# Altium schematic sheet receiver4.SchDoc(receiver4)
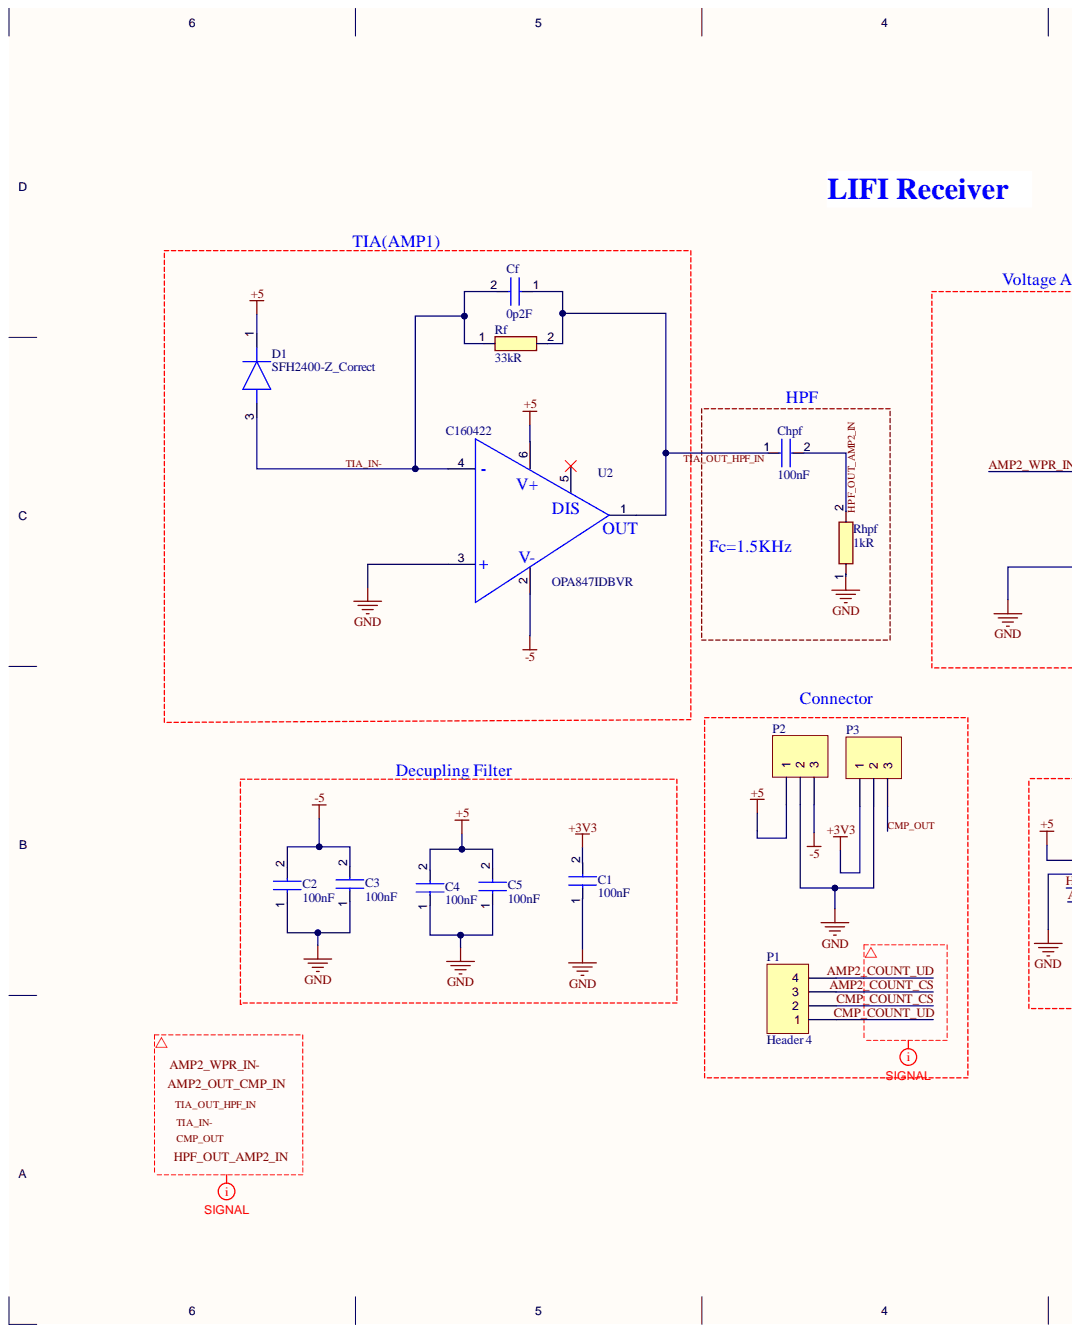
[diagram p0: receiver4.SchDoc, left]
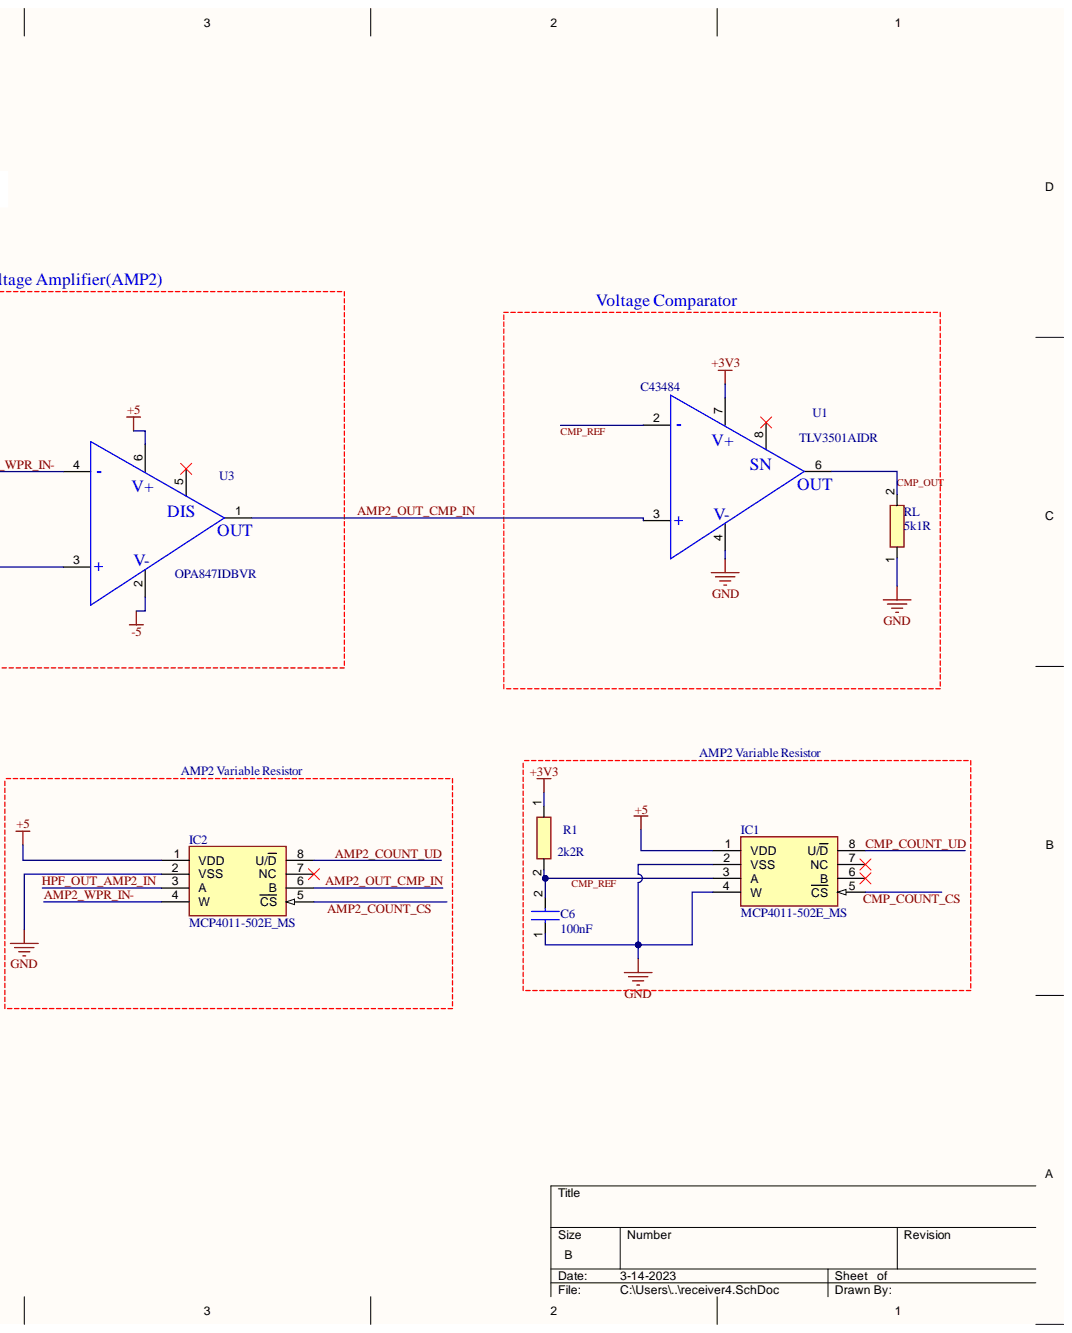
[diagram p1: receiver4.SchDoc, right]

## Parts
C1  100nF  [0603C]
C2  100nF  [0603C]
C3  100nF  [0603C]
C4  100nF  [0603C]
C5  100nF  [0603C]
C6  100nF  [0603C]
Cf  0p2F  [0402C]
Chpf  100nF  [0603C]
D1  SFH2400-Z_Correct  [SFH 2400-Z - duplicate]
IC1  MCP4011-502E_MS  [MCP4011_SOP65P490X110-8N]
IC2  MCP4011-502E_MS  [MCP4011_SOP65P490X110-8N]
P1  Header 4  [HDR1X4]
P2  (no value)  [HDR1X3]
P3  ⠀  [HDR1X3]  (value not drawn)
R1  2k2R  [0402R]
Rf  33kR  [0603R]
Rhpf  1kR  [0603R]
RL  5k1R  [0603R]
U1  TLV3501AIDR  Supplier Part Number 1: C43484  [TLV3501AIDR]
U2  OPA847IDBVR  Supplier Part Number 1: C160422  [OPA847IDBVR]
U3  OPA847IDBVR  [OPA847IDBVR]

## Nets
+3V3: C1.2 P3.1 R1.1 U1.7(V+)
+5: C4.2 C5.2 D1.1 IC1.1(VDD) IC2.1(VDD) P2.1 U2.6(V+) U3.6(V+)
-5: C2.2 C3.2 P2.3 U2.2(V-) U3.2(V-)
GND: C1.1 C2.1 C3.1 C4.1 C5.1 C6.1 IC1.2(VSS) IC1.4(W) IC2.2(VSS) P2.2 P3.2 Rhpf.1 RL.1 U1.4(V-) U2.3(+) U3.3(+)
AMP2_COUNT_CS: IC2.5(CS) P1.3
AMP2_COUNT_UD: IC2.8(U/D) P1.4
AMP2_OUT_CMP_IN: IC2.6(B) U1.3(+) U3.1(DIS)
AMP2_WPR_IN-: IC2.4(W) U3.4(-)
CMP_COUNT_CS: IC1.5(CS) P1.2
CMP_COUNT_UD: IC1.8(U/D) P1.1
CMP_OUT: P3.3 RL.2 U1.6
CMP_REF: C6.2 IC1.3(A) R1.2 U1.2(-)
HPF_OUT_AMP2_IN: Chpf.2 IC2.3(A) Rhpf.2
TIA_IN-: Cf.2 D1.3 Rf.1 U2.4(-)
TIA_OUT_HPF_IN: Cf.1 Chpf.1 Rf.2 U2.1(DIS)

Not connected: IC1 6(B) 7(NC); IC2 7(NC); U1 8(SN); U2 5; U3 5

## Library data of the parts
C1-C6 Chpf = 0603C: Component Kind: Standard; Library Name: Schlib_Receiver.SchLib
Cf = 0402C: Component Kind: Standard; Library Name: Schlib_Receiver.SchLib
D1 = SFH2400-Z_Correct: Silicon PIN photodiode, SMT, SFH2400; Manufacturer: Osram; Dark Current: 1nA; Fall Time: 5ns; Forward Voltage: 1.3V; Lens Style: TRANSPARENT; Max Power Dissipation: 120mW; Max Reverse Voltage (DC): 20V; Responsivity: 0.65A/W; Reverse Breakdown Voltage: 50V; Viewing Angle: 120°; Wavelength: 850nm; Case/Package: SMD/SMT; Category: LEDs; Component Kind: Standard; ComponentLink1Description: https://datasheet.ciiva.com/4697/fh2400-rpb-free-2011-02-08-16784-4697649.pdf?src-supplier=Mouser; ComponentLink1URL: https://datasheet.ciiva.com/4697/fh2400-rpb-free-2011-02-08-16784-4697649.pdf?src-supplier=Mouser; ComponentLink2Description: https://datasheet.ciiva.com/1248/36138-1248870.pdf?src-supplier=Element14; ComponentLink2URL: https://datasheet.ciiva.com/1248/36138-1248870.pdf?src-supplier=Element14; ComponentLink3Description: https://datasheet.ciiva.com/2820/00131266-0-2820020.pdf?src-supplier=Digi-Key; ComponentLink3URL: https://datasheet.ciiva.com/2820/00131266-0-2820020.pdf?src-supplier=Digi-Key; ComponentLink4Description: https://datasheet.ciiva.com/2820/00131266-0-2820021.pdf?src-supplier=Digi-Key; ComponentLink4URL: https://datasheet.ciiva.com/2820/00131266-0-2820021.pdf?src-supplier=Digi-Key; ComponentLink5Description: https://datasheet.ciiva.com/2820/00131266-0-2820022.pdf?src-supplier=Digi-Key; ComponentLink5URL: https://datasheet.ciiva.com/2820/00131266-0-2820022.pdf?src-supplier=Digi-Key; ComponentLink6Description: https://datasheet.ciiva.com/1248/36138-1248871.pdf?src-supplier=Element14; ComponentLink6URL: https://datasheet.ciiva.com/1248/36138-1248871.pdf?src-supplier=Element14; Diode Type: PIN; Height: 1.05mm; Length: 2.1mm; Library Name: Schlib_Receiver.SchLib; Manufacturer Part Number: SFH2400-Z; Max Operating Temperature: 100°C; Min Operating Temperature: -40°C; Mount: Surface Mount; Number of Pins: 3; Package Quantity: 1; Packaging: Tape and Reel; Power Dissipation: 120mW; Pricing 1: 1=1.19000, 10=0.75200, 50=0.75200, 100=0.55700, 1000=0.42200, 10000=0.38900 (USD); Radiation Hardening: No; REACH SVHC: No SVHC; Response Time: 5ns; Reverse Voltage (DC): 20V; Rise Time: 5ns; RoHS: Yes; RoHS Compliant: Yes; Series: -; Stock 1: 10986; Supplier 1: Mouser; Width: 2.7mm
IC1 IC2 = MCP4011-502E_MS: Integrated Circuit; Manufacturer_Name: Microchip; Manufacturer_Part_Number: MCP4011-502E/MS; Arrow Part Number: MCP4011-502E/MS; Arrow Price/Stock: https://www.arrow.com/en/products/mcp4011-502ems/microchip-technology?region=nac; Component Kind: Standard; Datasheet Link: https://datasheet.datasheetarchive.com/originals/distributors/SFDatasheet-4/sf-00090078.pdf; Height: 1.1mm; Library Name: Schlib_Receiver.SchLib; Mouser Part Number: 579-MCP4011-502E/MS; Mouser Price/Stock: https://www.mouser.co.uk/ProductDetail/Microchip-Technology/MCP4011-502E-MS?qs=usxtMOJb1RzQTLKzDsZHHA%3D%3D
P1 = Header 4: Header, 4-Pin; Component Kind: Standard; LatestRevisionDate: 17-Jul-2002; LatestRevisionNote: Re-released for DXP Platform.; Library Name: Miscellaneous Connectors.IntLib; Signal Integrity: Connector; Publisher: Altium Limited
P2 P3 = Header 3: Header, 3-Pin; Component Kind: Standard; LatestRevisionDate: 17-Jul-2002; LatestRevisionNote: Re-released for DXP Platform.; Library Name: Miscellaneous Connectors.IntLib; Signal Integrity: Connector; Publisher: Altium Limited
R1 = 0402R: Component Kind: Standard; Library Name: Schlib_Receiver.SchLib
Rf Rhpf RL = 0603R: Component Kind: Standard; Library Name: Schlib_Receiver.SchLib
U1 = TLV3501AIDR: Comparator General Purpose CMOS, Push-Pull, Rail-to-Rail, TTL 8-SOIC; Manufacturer: Texas Instruments; Common Mode Rejection Ratio: 70dB; Hysteresis: 6mV; Input Bias Current: 10pA; Input Offset Voltage (Vos): 6.5mV; Max Supply Current: 5mA; Max Supply Voltage: 5.5V; Min Supply Voltage: 2.7V; Number of Channels: 1; Output Current: 74mA; Output Type: TTL,PUSH-PULL; Power Supply Rejection Ratio (PSRR): 100dB; Propagation Delay: 10ns; Response Time: 0.0045us; Case/Package: SOIC; Category: Amplifiers - Op Amps, Buffer, Instrumentation; Component Kind: Standard; ComponentLink1Description: https://datasheet.ciiva.com/1830/tlv3501-1830016.pdf?src-supplier=Digi-Key; ComponentLink1URL: https://datasheet.ciiva.com/1830/tlv3501-1830016.pdf?src-supplier=Digi-Key; ComponentLink2Description: https://datasheet.ciiva.com/14392/tlv3501-14392108.pdf?src-supplier=Avnet; ComponentLink2URL: https://datasheet.ciiva.com/14392/tlv3501-14392108.pdf?src-supplier=Avnet; Lead Free: Lead Free; Library Name: Schlib_Receiver.SchLib; Manufacturer Part Number: TLV3501AIDR; Max Input Current: 10pA; Max Operating Temperature: 125°C; Min Operating Temperature: -40°C; Mount: Surface Mount; Nominal Supply Current: 5mA; Number of Elements: 1; Number of Pins: 8; Package Quantity: 2500; Packaging: Tape and Reel; Pricing 1: 1=3.137700, 10=2.699600, 30=2.427300, 100=2.145900, 500=2.019700, 1000=1.963800 (AUD); Quiescent Current: 5mA; Radiation Hardening: No; RoHS: Yes; RoHS Compliant: Yes; Series: -; Stock 1: 1957; Supplier 1: LCSC; Supply Current: 5mA; Technology: CMOS; Type: General Purpose; Weight: 0.002677oz
U2 U3 = OPA847IDBVR: Op Amp Single High Speed Amplifier ±6V/12V 6-Pin SOT-23 T/R / IC OPAMP VFB 3.9GHZ SOT23-6; Manufacturer: Texas Instruments; Common Mode Rejection Ratio: 95dB; Dual Supply Voltage: 5V; Input Bias Current: 42uA; Input Offset Voltage (Vos): 500uV; Max Dual Supply Voltage: 6V; Max Supply Voltage: 12V; Min Dual Supply Voltage: 2.5V; Min Supply Voltage: 10V; Nominal Supply Current: 18.4mA; Number of Channels: 1; Operating Supply Current: 18.1mA; Output Current: 75mA; Output Current per Channel: 100mA; Settling Time: 20ns; Slew Rate: 950V/µs; Voltage Gain: 98dB; Bandwidth: 3.9GHz; Case/Package: SOT-23-6; Category: Amplifiers - Op Amps, Buffer, Instrumentation; Component Kind: Standard; ComponentLink1Description: https://datasheet.ciiva.com/14457/sbos251e-14457510.pdf?src-supplier=Avnet; ComponentLink1URL: https://datasheet.ciiva.com/14457/sbos251e-14457510.pdf?src-supplier=Avnet; ComponentLink2Description: https://datasheet.ciiva.com/1816/opa847-1816108.pdf?src-supplier=Digi-Key; ComponentLink2URL: https://datasheet.ciiva.com/1816/opa847-1816108.pdf?src-supplier=Digi-Key; Contact Plating: Gold; Gain Bandwidth Product: 3.9GHz; Height: 1.15mm; Lead Free: Lead Free; Length: 2.9mm; Library Name: Schlib_Receiver.SchLib; Manufacturer Part Number: OPA847IDBVR; Max I/O Voltage: 500uV; Max Operating Temperature: 85°C; Min Operating Temperature: -40°C; Number of Circuits: 1; Number of Elements: 1; Number of Pins: 6; Package Quantity: 3000; Packaging: Tape and Reel; Power Supply Rejection Ratio (PSRR): 95dB; Pricing 1: 1=6.46000, 10=5.80000, 50=5.48000, 100=4.75000, 1000=3.54000, 10000=3.53000 (USD); Radiation Hardening: No; RoHS: Yes; RoHS Compliant: Yes; Unity Gain Bandwidth Product: 3.9GHz; Width: 1.6mm
  U2: Supplier 1: LCSC
  U3: Supplier 1: Mouser
